# Altium schematic sheet SH5 - RGB LED.SchDoc
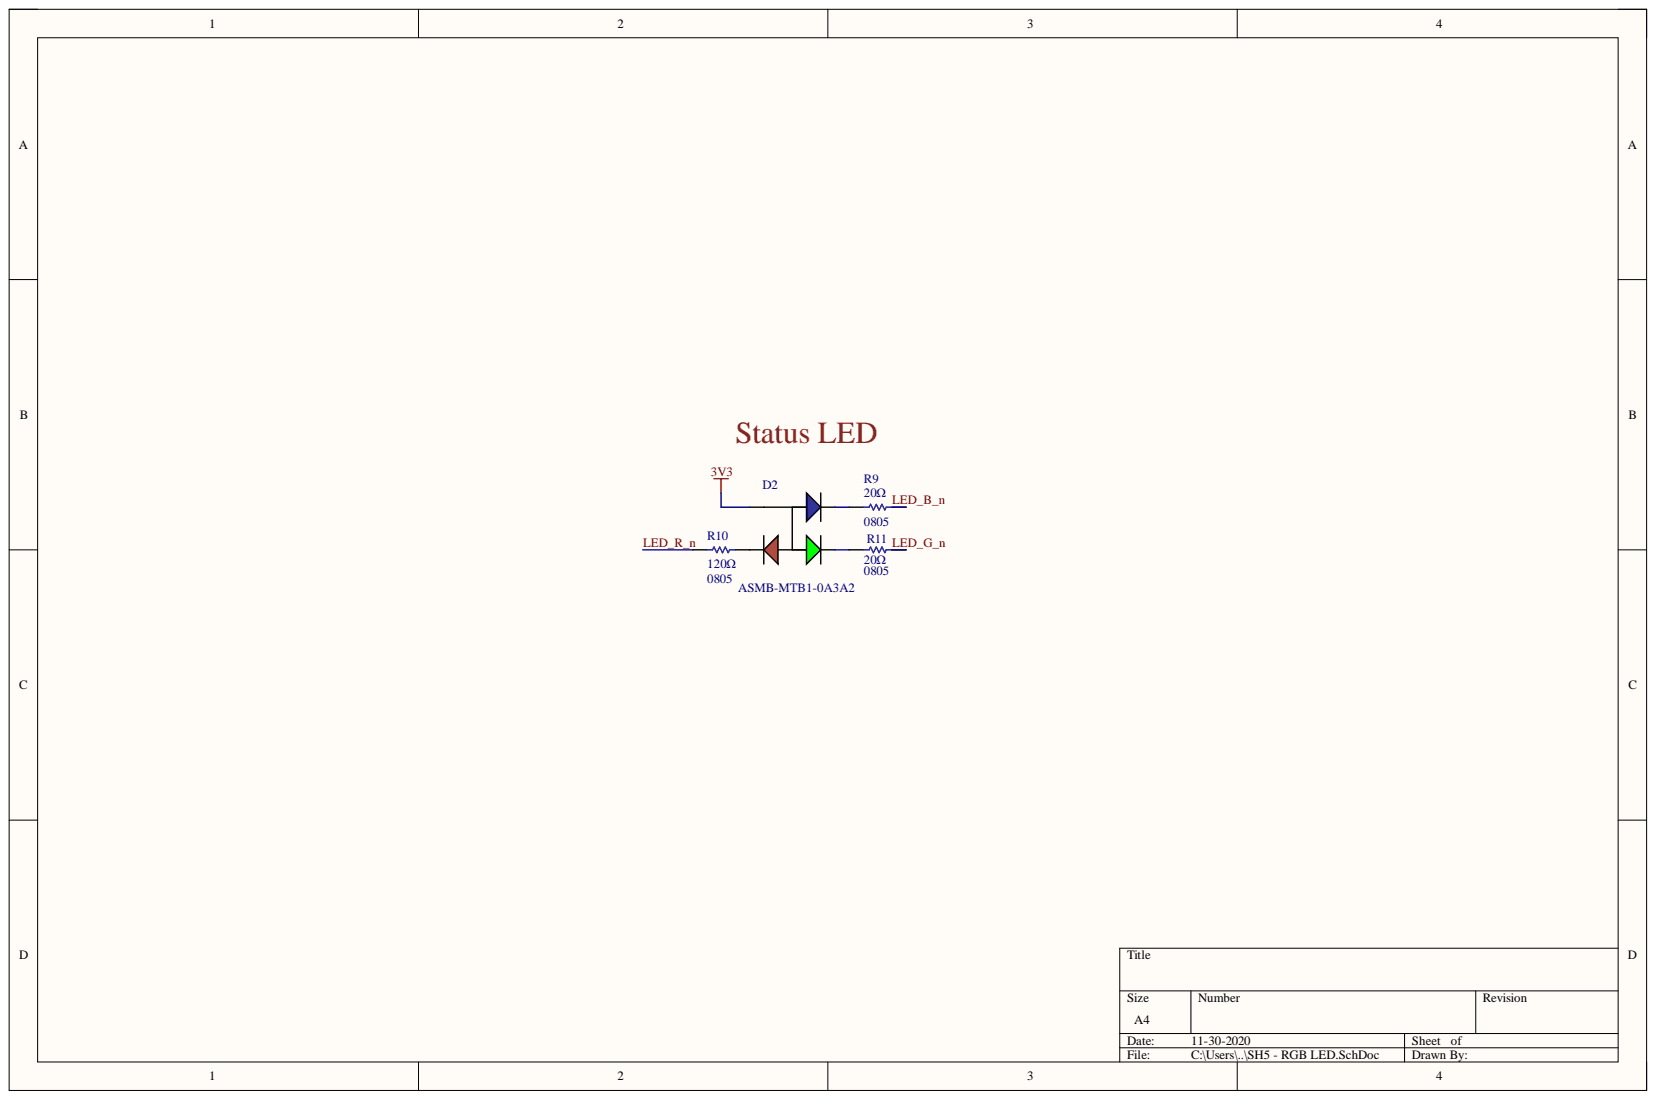
[diagram p0: SH5 - RGB LED.SchDoc]

## Parts
D2  Manufacturer Part Number 1: ASMB-MTB1-0A3A2  [LED_RGB]  (hidden comment: LED_RGB)
R9  value 20Ω  Case Code (Imperial): 0805  [RESC0805]  (hidden comment: RES_20Ω_0805)
R10  Case Code (Imperial): 0805  Resistance: 120Ω  [RESC0805]  (hidden comment: RES_120Ω_0805_1%)
R11  value 20Ω  Case Code (Imperial): 0805  [RESC0805]  (hidden comment: RES_20Ω_0805)

## Nets
3V3: D2.4
LED_B_n: R9.2
LED_G_n: R11.2
LED_R_n: R10.1
NetD2_1: D2.1 R10.2
NetD2_2: D2.2 R11.1
NetD2_3: D2.3 R9.1

## Library data of the parts
D2 = LED_RGB: LED RGB DIFFUSED 4PLCC SMD; Manufacturer 1: Broadcom; Color: Blue,Green,Red; Dominant Wavelength: 470nm; Forward Voltage: 3.1V; Lens Color: White; Lens Style: Round; Luminous Intensity: 350mcd; Test Current: 20mA; Viewing Angle: 115°; Case/Package: PLCC; Component Kind: Standard; Height: 1.9mm; Length: 3.2mm; Lens Transparency: Diffused; Mount: Surface Mount; Number of Pins: 4; REACH SVHC: No SVHC; Supplier 1: Digi-Key; Supplier Part Number 1: 516-3280-1-ND; Width: 2.8mm; Library Name: UWRT_Schematic_Library_2020.SchLib
R9 R11 = RES_20Ω_0805_1%: RES 20 OHM 1% 1/8W 0805; Manufacturer 1: Yageo; Manufacturer Part Number 1: RC0805FR-0720RL; Power Rating: 125mW; Resistance: 20; Case Code (Metric): 2012; Component Kind: Standard; Max Operating Temperature: 155°C; Min Operating Temperature: -55°C; Package: 0805 (2012 Metric); Supplier 1: Digi-Key; Supplier Part Number 1: 311-20.0CRCT-ND; Termination: SMD/SMT; Tolerance: 1%; Library Name: UWRT_Schematic_Library_2020.SchLib
R10 = RES_120Ω_0805_1%: RES 120 OHM 1% 1/8W 0805; Manufacturer 1: Vishay; Manufacturer Part Number 1: CRCW0805120RFKEA; Power Rating: 125mW; Voltage Rating: 150V; Component Kind: Standard; Mount: Surface Mount; Supplier 1: Digi-Key; Supplier Part Number 1: 541-120CCT-ND; Library Name: UWRT_Schematic_Library_2020.SchLib
